# Altium schematic sheet P06_BLE.SchDoc
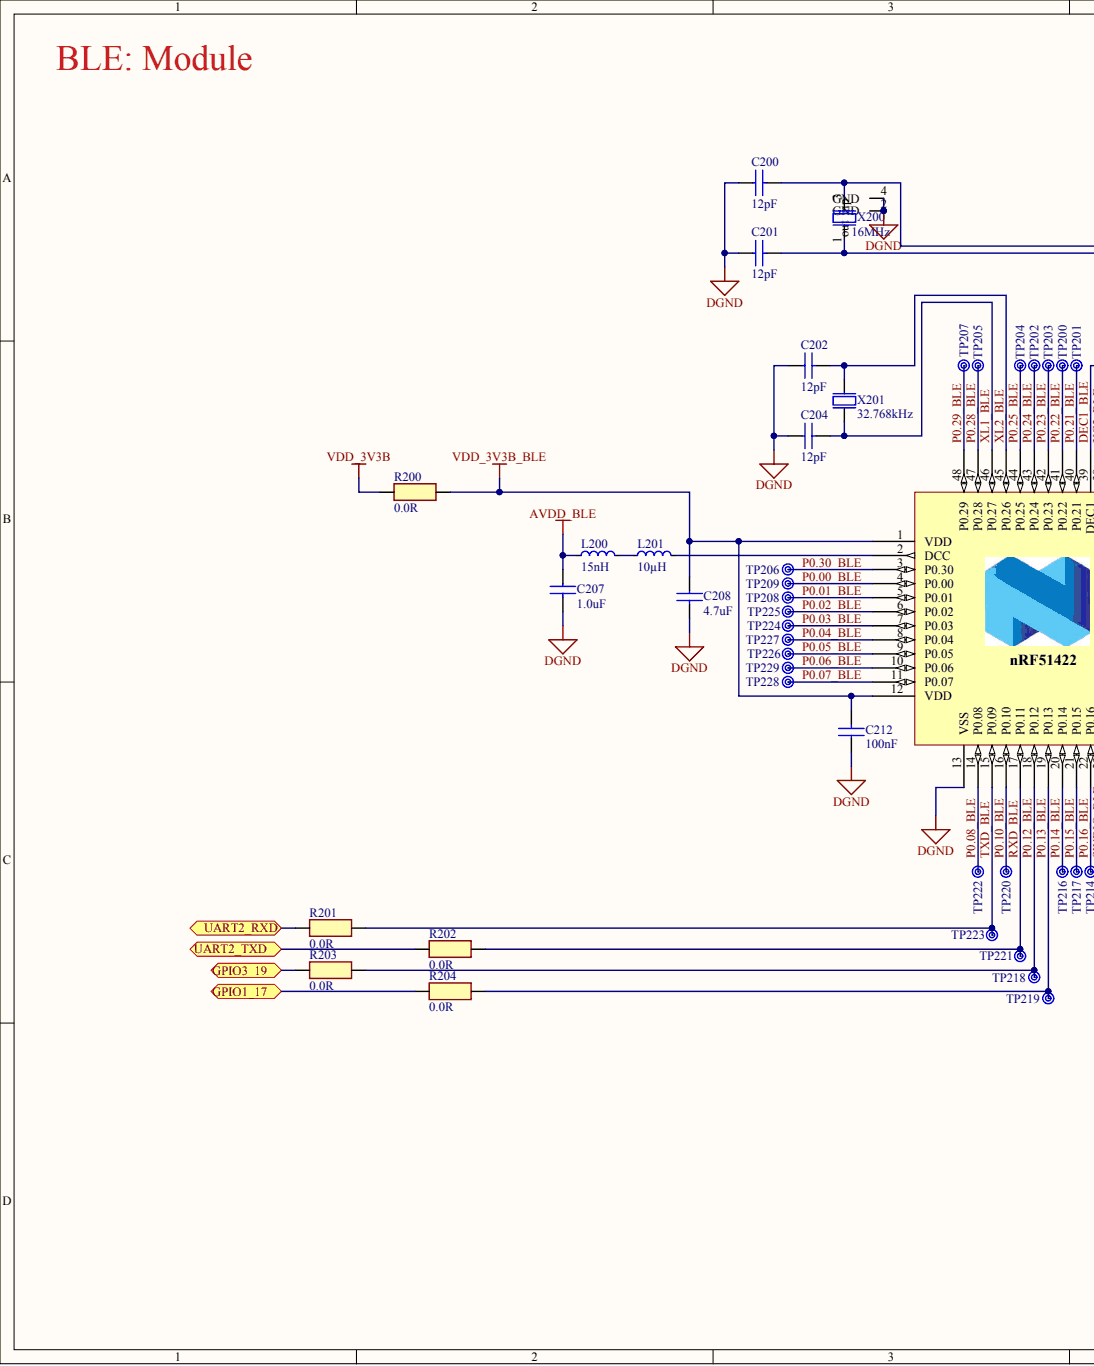
[diagram p0: P06_BLE.SchDoc, left]
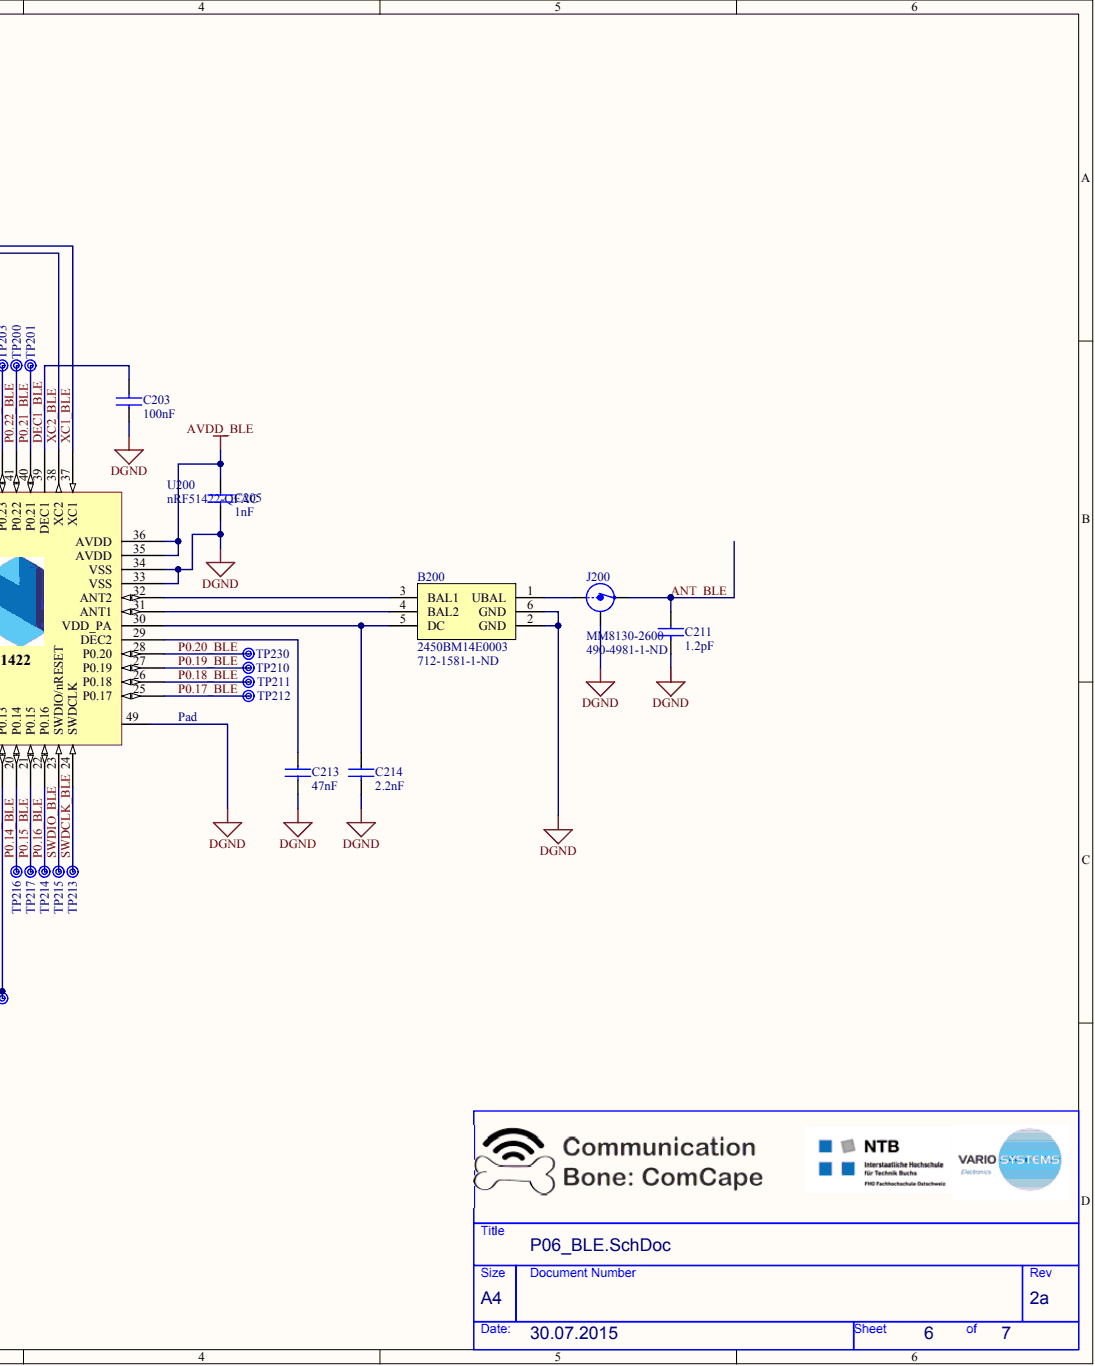
[diagram p1: P06_BLE.SchDoc, right]

## Parts
B200  2450BM14E0003  Supplier Part Number 1: 712-1581-1-ND  [BAL-0603-6pin]
C200  12pF  [SM/C_0603]
C201  12pF  [SM/C_0603]
C202  12pF  [SM/C_0603]
C203  100nF  [SM/C_0603]
C204  12pF  [SM/C_0603]
C205  1nF  [SM/C_0603]
C207  1.0uF  [SM/C_0603]
C208  4.7uF  [SM/C_0603]
C211  1.2pF  [SM/C_0402]
C212  100nF  [SM/C_0603]
C213  47nF  [CAPC1005X04L]
C214  2.2nF  [CAPC1005X04L]
J200  MM8130-2600  [COAXIAL-SWF]
L200  15nH
L201  10µH  [INDC1608X06L]
R200  0.0R  [SM/R_0603]
R201  0.0R  [SM/R_0603]
R202  0.0R  [SM/R_0603]
R203  0.0R  [SM/R_0603]
R204  0.0R  [SM/R_0603]
TP200  Testpoint mit Bohrung  [TP_mit_0.7mm_Bohrung]  (value not drawn)  (no BOM)
TP201  Testpoint mit Bohrung  [TP_mit_0.7mm_Bohrung]  (value not drawn)  (no BOM)
TP202  Testpoint mit Bohrung  [TP_mit_0.7mm_Bohrung]  (value not drawn)  (no BOM)
TP203  Testpoint mit Bohrung  [TP_mit_0.7mm_Bohrung]  (value not drawn)  (no BOM)
TP204  Testpoint mit Bohrung  [TP_mit_0.7mm_Bohrung]  (value not drawn)  (no BOM)
TP205  Testpoint mit Bohrung  [TP_mit_0.7mm_Bohrung]  (value not drawn)  (no BOM)
TP206  Testpoint mit Bohrung  [TP_mit_0.7mm_Bohrung]  (value not drawn)  (no BOM)
TP207  Testpoint mit Bohrung  [TP_mit_0.7mm_Bohrung]  (value not drawn)  (no BOM)
TP208  Testpoint mit Bohrung  [TP_mit_0.7mm_Bohrung]  (value not drawn)  (no BOM)
TP209  Testpoint mit Bohrung  [TP_mit_0.7mm_Bohrung]  (value not drawn)  (no BOM)
TP210  Testpoint mit Bohrung  [TP_mit_0.7mm_Bohrung]  (value not drawn)  (no BOM)
TP211  Testpoint mit Bohrung  [TP_mit_0.7mm_Bohrung]  (value not drawn)  (no BOM)
TP212  Testpoint mit Bohrung  [TP_mit_0.7mm_Bohrung]  (value not drawn)  (no BOM)
TP213  Testpoint mit Bohrung  [TP_mit_0.7mm_Bohrung]  (value not drawn)  (no BOM)
TP214  Testpoint mit Bohrung  [TP_mit_0.7mm_Bohrung]  (value not drawn)  (no BOM)
TP215  Testpoint mit Bohrung  [TP_mit_0.7mm_Bohrung]  (value not drawn)  (no BOM)
TP216  Testpoint mit Bohrung  [TP_mit_0.7mm_Bohrung]  (value not drawn)  (no BOM)
TP217  Testpoint mit Bohrung  [TP_mit_0.7mm_Bohrung]  (value not drawn)  (no BOM)
TP218  Testpoint mit Bohrung  [TP_mit_0.7mm_Bohrung]  (value not drawn)  (no BOM)
TP219  Testpoint mit Bohrung  [TP_mit_0.7mm_Bohrung]  (value not drawn)  (no BOM)
TP220  Testpoint mit Bohrung  [TP_mit_0.7mm_Bohrung]  (value not drawn)  (no BOM)
TP221  Testpoint mit Bohrung  [TP_mit_0.7mm_Bohrung]  (value not drawn)  (no BOM)
TP222  Testpoint mit Bohrung  [TP_mit_0.7mm_Bohrung]  (value not drawn)  (no BOM)
TP223  Testpoint mit Bohrung  [TP_mit_0.7mm_Bohrung]  (value not drawn)  (no BOM)
TP224  Testpoint mit Bohrung  [TP_mit_0.7mm_Bohrung]  (value not drawn)  (no BOM)
TP225  Testpoint mit Bohrung  [TP_mit_0.7mm_Bohrung]  (value not drawn)  (no BOM)
TP226  Testpoint mit Bohrung  [TP_mit_0.7mm_Bohrung]  (value not drawn)  (no BOM)
TP227  Testpoint mit Bohrung  [TP_mit_0.7mm_Bohrung]  (value not drawn)  (no BOM)
TP228  Testpoint mit Bohrung  [TP_mit_0.7mm_Bohrung]  (value not drawn)  (no BOM)
TP229  Testpoint mit Bohrung  [TP_mit_0.7mm_Bohrung]  (value not drawn)  (no BOM)
TP230  Testpoint mit Bohrung  [TP_mit_0.7mm_Bohrung]  (value not drawn)  (no BOM)
U200  nRF51422-QFAC  [QFN40P600X600X90-48N]
X200  16MHz  [BT-XTAL_2520]
X201  32.768kHz  [XTAL_3215]

## Ports
GPIO1_17, GPIO3_19, UART2_RXD, UART2_TXD

## Nets
AVDD_BLE: C205.1 C207.1 L200.2 U200.35(AVDD) U200.36(AVDD)
DGND: B200.2(GND) B200.6(GND) C200.1 C201.1 C202.1 C203.1 C204.1 C205.2 C207.2 C208.2 C211.2 C212.1 C213.2 C214.2 J200.2 U200.13(VSS) U200.33(VSS) U200.34(VSS) U200.49 X200.2(GND) X200.4(GND)
VDD_3V3B: R200.1
VDD_3V3B_BLE: C208.1 C212.2 R200.2 U200.1(VDD) U200.12(VDD)
ANT_BLE: C211.1 J200.3
DEC1_BLE: C203.2 U200.39(DEC1)
GPIO1_17: R204.1 ; port GPIO1_17
GPIO3_19: R203.1 ; port GPIO3_19
P0.00_BLE: TP209.1 U200.4(P0.00)
P0.01_BLE: TP208.1 U200.5(P0.01)
P0.02_BLE: TP225.1 U200.6(P0.02)
P0.03_BLE: TP224.1 U200.7(P0.03)
P0.04_BLE: TP227.1 U200.8(P0.04)
P0.05_BLE: TP226.1 U200.9(P0.05)
P0.06_BLE: TP229.1 U200.10(P0.06)
P0.07_BLE: TP228.1 U200.11(P0.07)
P0.08_BLE: TP222.1 U200.14(P0.08)
P0.10_BLE: TP220.1 U200.16(P0.10)
P0.12_BLE: R203.2 TP218.1 U200.18(P0.12)
P0.13_BLE: R204.2 TP219.1 U200.19(P0.13)
P0.14_BLE: TP216.1 U200.20(P0.14)
P0.15_BLE: TP217.1 U200.21(P0.15)
P0.16_BLE: TP214.1 U200.22(P0.16)
P0.17_BLE: TP212.1 U200.25(P0.17)
P0.18_BLE: TP211.1 U200.26(P0.18)
P0.19_BLE: TP210.1 U200.27(P0.19)
P0.20_BLE: TP230.1 U200.28(P0.20)
P0.21_BLE: TP201.1 U200.40(P0.21)
P0.22_BLE: TP200.1 U200.41(P0.22)
P0.23_BLE: TP203.1 U200.42(P0.23)
P0.24_BLE: TP202.1 U200.43(P0.24)
P0.25_BLE: TP204.1 U200.44(P0.25)
P0.28_BLE: TP205.1 U200.47(P0.28)
P0.29_BLE: TP207.1 U200.48(P0.29)
P0.30_BLE: TP206.1 U200.3(P0.30)
RXD_BLE: R202.2 TP221.1 U200.17(P0.11)
SWDCLK_BLE: TP213.1 U200.24(SWDCLK)
SWDIO_BLE: TP215.1 U200.23(SWDIO/nRESET)
TXD_BLE: R201.2 TP223.1 U200.15(P0.09)
UART2_RXD: R201.1 ; port UART2_RXD
UART2_TXD: R202.1 ; port UART2_TXD
XC1_BLE: C200.2 U200.37(XC1) X200.3
XC2_BLE: C201.2 U200.38(XC2) X200.1
XL1_BLE: C204.2 U200.46(P0.27) X201.1
XL2_BLE: C202.2 U200.45(P0.26) X201.2
NetB200_1: B200.1(UBAL) J200.1
NetB200_3: B200.3(BAL1) U200.32(ANT2)
NetB200_4: B200.4(BAL2) U200.31(ANT1)
NetB200_5: B200.5(DC) C214.1 U200.30(VDD_PA)
NetC213_1: C213.1 U200.29(DEC2)
NetL200_1: L200.1 L201.2
NetL201_1: L201.1 U200.2(DCC)

## Library data of the parts
B200 = (no symbol name): Johanson Technology 2.45 GHz Impedance Matched Balun-Filter; Note: Optimized for nRF51x22-QFAA; Component Kind: Standard; Footprint Doc: BAL-0603-6pin; Manufacturer Part Number: 2450BM14E0003; Pin Count: 6; Supplier 1: Digi-Key; Value: 2450BM14E0003; Library Name: ComCape.SchLib
C200-C202 C204 = SC CAP __0603 _12p00 _50V ____5% NP0 ___: Manufacturer: Generic Component; Part Number: 235651; Cust: Y; HTNr: take notice of key data; Std: STD SET; Tolerance: 5%; Voltage: 50V; Component Kind: Standard; Description: SC CAP __0603 _12p00 _50V ____5% NP0 ___; Part Type: CAP-SMD\Keramik\0603; PCB Footprint: SM/C_0603; Supplier 1: Digi-Key; Supplier Part Number 1: 1276-1254-1-ND; Value: 12pF; Library Name: ComCape.SchLib
C203 C212 = (no symbol name): SC CAP __0603 100n00 _16V ___10% X7R ___; Manufacturer: Generic Component; Part Number: 209336; Cust: Y; HTNr: take notice of key data; Tolerance: 10%; Voltage: 16V; Component Kind: Standard; Part Type: CAP-SMD\Keramik\0603; PCB Footprint: SM/C_0603; Std: STD; Supplier 1: Digi-Key; Supplier Part Number 1: 399-1096-1-ND; Value: 100nF; Library Name: ComCape.SchLib
C205 = SC CAP __0603 __1n00 _50V ___10% X7R ___: Manufacturer: Generic Component; Part Number: 203072; Cust: Y; HTNr: take notice of key data; Std: STD SET; Tolerance: 10%; Voltage: 50V; Component Kind: Standard; Description: SC CAP __0603 __1n00 _50V ___10% X7R ___; Part Type: CAP-SMD\Keramik\0603; PCB Footprint: SM/C_0603; Supplier 1: Digi-Key; Supplier Part Number 1: 399-1082-1-ND; Value: 1nF; Library Name: ComCape.SchLib
C207 = (no symbol name): SC CAP __0603 __1u00 _16V ___10% X7R ___; Manufacturer: Generic Component; Part Number: 243307; Cust: Y; HTNr: take notice of key data; Tolerance: 10%; Value: 1uF; Voltage: 16V; Component Kind: Standard; Part Type: CAP-SMD\Keramik\0603; PCB Footprint: SM/C_0603; Std: STD; Supplier 1: Digi-Key; Supplier Part Number 1: 1276-1019-1-ND; Library Name: ComCape.SchLib
C208 = (no symbol name): SC CAP __0603 __4U70 _6V3 ___10% X5R ___; Manufacturer: Murata; Part Number: 246081; Cust: Y; HTNr: GRM188R60J475KE19D; Tolerance: 10%; Voltage: 6V3; Component Kind: Standard; Part Type: CAP-SMD\Keramik\0603; PCB Footprint: SM/C_0603; Std: STD; Supplier 1: Digi-Key; Supplier Part Number 1: 1276-1045-1-ND; Value: 4.7uF; Datasheet: S:\Datenb\DS-230220-000B.pdf; Library Name: ComCape.SchLib
C211 = SC CAP __0402 __1P20 _50V ___P10 NP0 _HG: Manufacturer: Johanson Dielectrics; Part Number: 2005874; Cust: Y; HTNr: 500R07S1R2BV4T; Voltage: 50V; Component Kind: Standard; Description: SC CAP __0402 __1P20 _50V ___P10 NP0 _HG; Part Type: CAP-SMD\Keramik\0402; PCB Footprint: SM/C_0402; Std: STD; Supplier 1: Digi-Key; Supplier Part Number 1: 712-1268-1-ND; Value: 1.2pF; Datasheet: S:\Datenb\DS-2005218-000A.pdf; Library Name: ComCape.SchLib
C213 = SC CAP __0402 _47N00 _25V ___10% X7R ___: Manufacturer: Generic Component; Part Number: 248028; Cust: Y; HTNr: take notice of key data; PCB Footprint: SM/C_0402; Std: STD SET; Tolerance: 10%; Voltage: 25V; Component Kind: Standard; Description: SC CAP __0402 _47N00 _25V ___10% X7R ___; Part Type: CAP-SMD\Keramik\0402; Supplier 1: Mouser; Supplier Part Number 1: 81-GRM155R71E473KA8J; Value: 47nF; Library Name: ComCape.SchLib
C214 = SC CAP __0402 __2N20 _50V ___10% X7R ___: Manufacturer: Generic Component; Part Number: 241763; Cust: Y; HTNr: take notice of key data; PCB Footprint: SM/C_0402; Std: STD SET; Tolerance: 10%; Voltage: 50V; Component Kind: Standard; Description: SC CAP __0402 __2N20 _50V ___10% X7R ___; Part Type: CAP-SMD\Keramik\0402; Supplier 1: Digi-Key; Supplier Part Number 1: 1276-1054-1-ND; Value: 2.2nF; Library Name: ComCape.SchLib
J200 = (no symbol name): Coaxial Connector with Switch; 2nd source part number: 81-MM8130-2600RA2; Manufacturer: Murata; 2nd source Supplier: Mouser; Component Kind: Standard; Datasheet: http://www.murata.com/products/catalog/pdf/o33e.pdf; Footprint Doc: COAXIAL-SWF; Manufacturer Part Number: MM8130-2600; Pin Count: 3; Supplier 1: Digi-Key; Supplier Part Number 1: 490-4981-1-ND; Value: MM8130-2600; Library Name: ComCape.SchLib
L200 = (no symbol name): Inductor, 320mA, ±5%; 2nd source part number: 658-1328; Manufacturer: Taiyo Yuden; Manufacturer Part Number: HK100515NJ-T; Artikkelnr Noca: 33029323; Artikkelnr Simpro: N.A.; Note: High frequency chip inductor ±10%; 2nd source Supplier: Farnell; Component Kind: Standard; Datasheet: http://www.yuden.co.jp/productdata/catalog/en/mlci04_e.pdf; Pin Count: 2; Supplier 1: Digi-Key; Supplier Part Number 1: 587-1521-1-ND; Value: 15nH; Library Name: ComCape.SchLib
L201 = (no symbol name): Inductor, 80mA, ±10%; 2nd source part number: 178-6105; Manufacturer: Taiyo Yuden; Manufacturer Part Number: LBMF1608T100K; Note: LBMF1608T100K 10µH 80mA 0603; 2nd source Supplier: Farnell; Component Kind: Standard; Datasheet: http://www.yuden.co.jp/ut/product/pdf/elb2_e.pdf; Footprint Doc: 0603; Pin Count: 2; Supplier 1: Digi-Key; Supplier Part Number 1: 587-1714-1-ND; Value: 10µH; Library Name: ComCape.SchLib
R200-R204 = SC RES __0603 __0R00 __0W1 _____ T100 __: Manufacturer: Generic Component; Part Number: 206748; Cust: Y; HTNr: take notice of key data; Power: 0.1W; Std: STD SET; Tolerance: 1%; Component Kind: Standard; Description: SC RES __0603 __0R00 __0W1 _____ T100 __; Part Type: RES-SMD\0603; PCB Footprint: SM/R_0603; Supplier 1: Digi-Key; Supplier Part Number 1: 1276-3510-1-ND; Value: 0.0R; Library Name: ComCape.SchLib
TP200-TP230 = Testpoint mit Bohrung: TP_0.7mm_THRU; Library Name: ComCape.SchLib
U200 = (no symbol name): Multi-protocol Bluetooth Low Energy, ANT and 2.4GHz proprietary system-on-chip; Manufacturer: Nordic Semiconductor; Manufacturer Part Number: nRF51422-QFACAx; Part Number: nRF51422-QFAC-XLR3+; Note: nRF51422 - QFN48 6x6 0.4mm pitch; Component Kind: Standard; Pin Count: 48; Supplier 1: Digi-Key; Supplier Part Number 1: 1490-1040-1-ND; Value: nRF51422-QFAC; Library Name: ComCape.SchLib
X200 = (no symbol name): XTAL SMD 2520, 16MHz, 8pF, ±15ppm; Manufacturer: NDK; Manufacturer Part Number: NX2520SA-16MHZ-STD-CSW-5; Note: XTAL SMD 16.000MHz; Component Kind: Standard; Datasheet: http://www.ndk.com/images/products/catalog/c_NX2520SA-STD-CSW-5_e.pdf; Footprint Doc: BT-XTAL_2520; Pin Count: 4; Supplier 1: Digi-Key; Supplier Part Number 1: 644-1169-1-ND; Value: 16MHz; Library Name: ComCape.SchLib
X201 = (no symbol name): EPSON TOYOCOM - FC-135 32.768KHZ ±20PPM,9.0PF - CRYSTAL, SM, WATCH; 2nd source part number: 127-8037; Part Number: XTAL_FC-135 32.768k; Artikkelnr Noca: 22019091; Artikkelnr Simpro: N.A.; Note: XTAL SMD 32.768kHz, 3215; 2nd source Supplier: Farnell; Component Kind: Standard; Datasheet: http://www.farnell.com/datasheets/57480.pdf; Footprint Doc: XTAL_3215; LatestRevisionDate: 2014-03-27; Manufacturer: Epson Toyocom; Manufacturer Part Number: FC-135 32.768KHZ ±20PPM,9.0PF; Pin Count: 2; Published: 2010-10-18; Supplier: Digi-Key; Supplier 1: Farnell; Supplier 2: Digi-Key; Supplier part number: FC-135 32.7680KA-AC-ND; Supplier Part Number 1: 1278037; Supplier Part Number 2: FC-135 32.7680KA-AC-ND; Value: 32.768kHz; Library Name: ComCape.SchLib; Publisher: Nordic Semiconductor
